# Altium schematic sheet [07] SYS_I2C_UART.SchDoc("[07] SYS_I2C_UART")
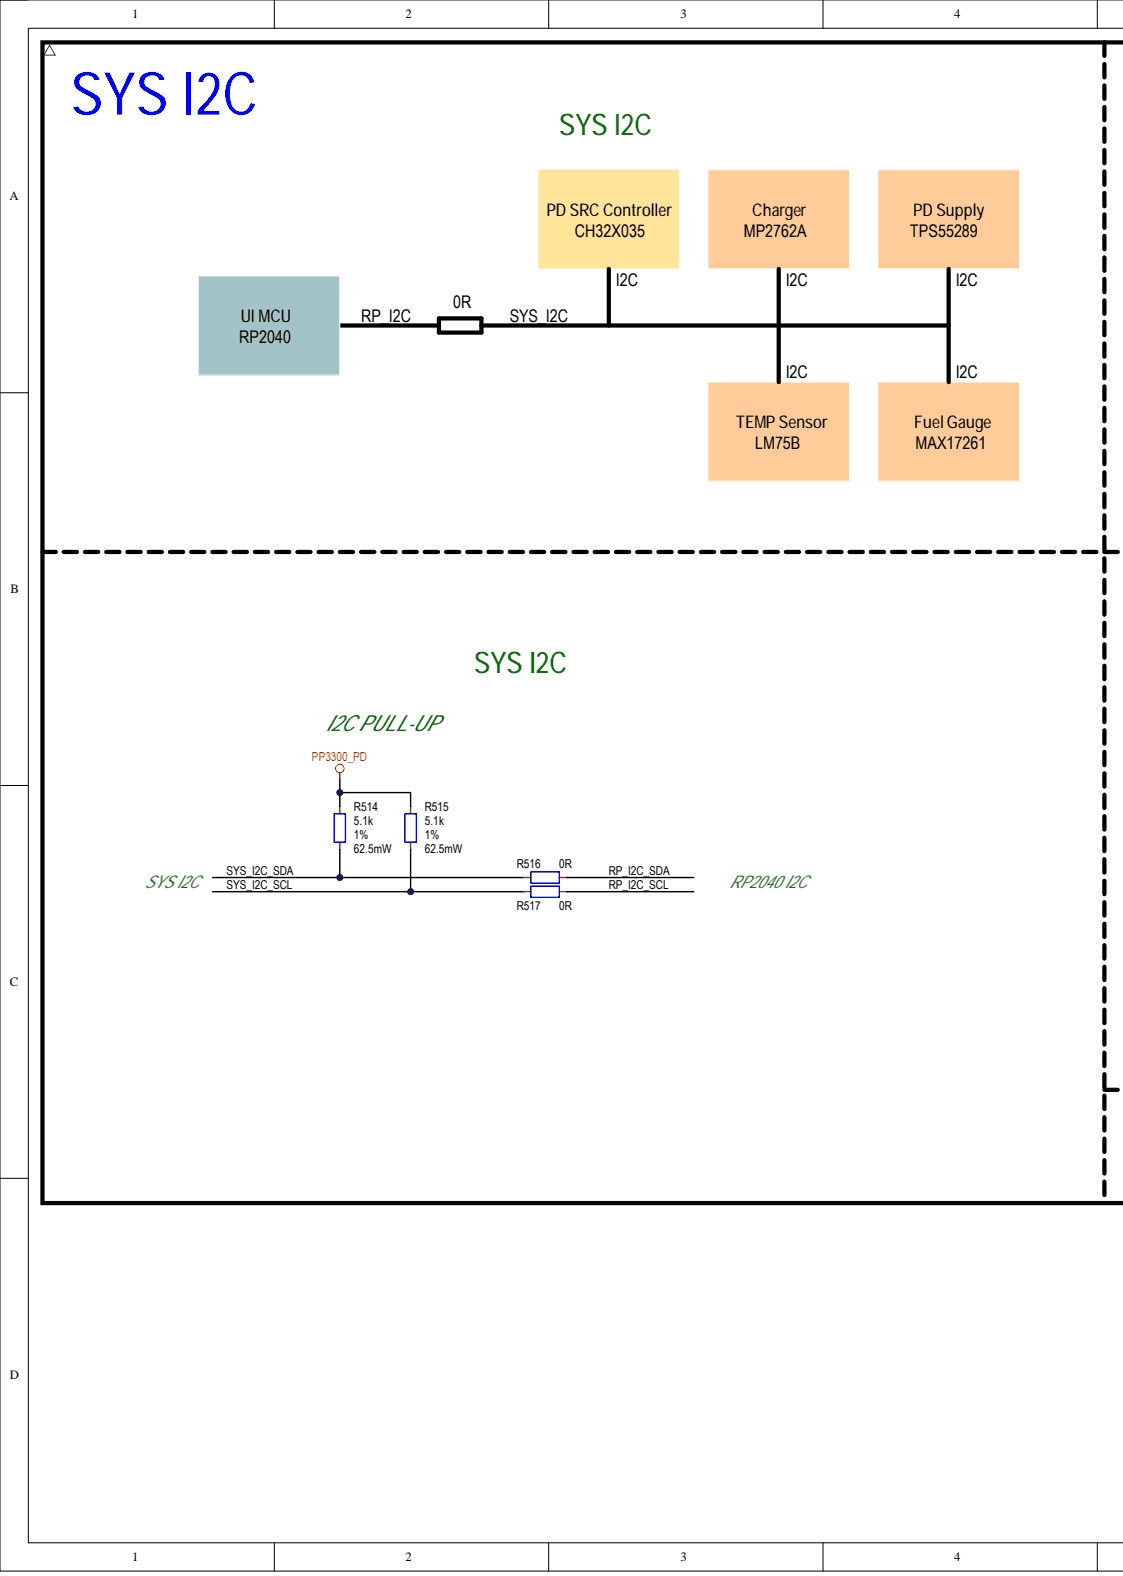
[diagram p0: [07] SYS_I2C_UART.SchDoc, left]
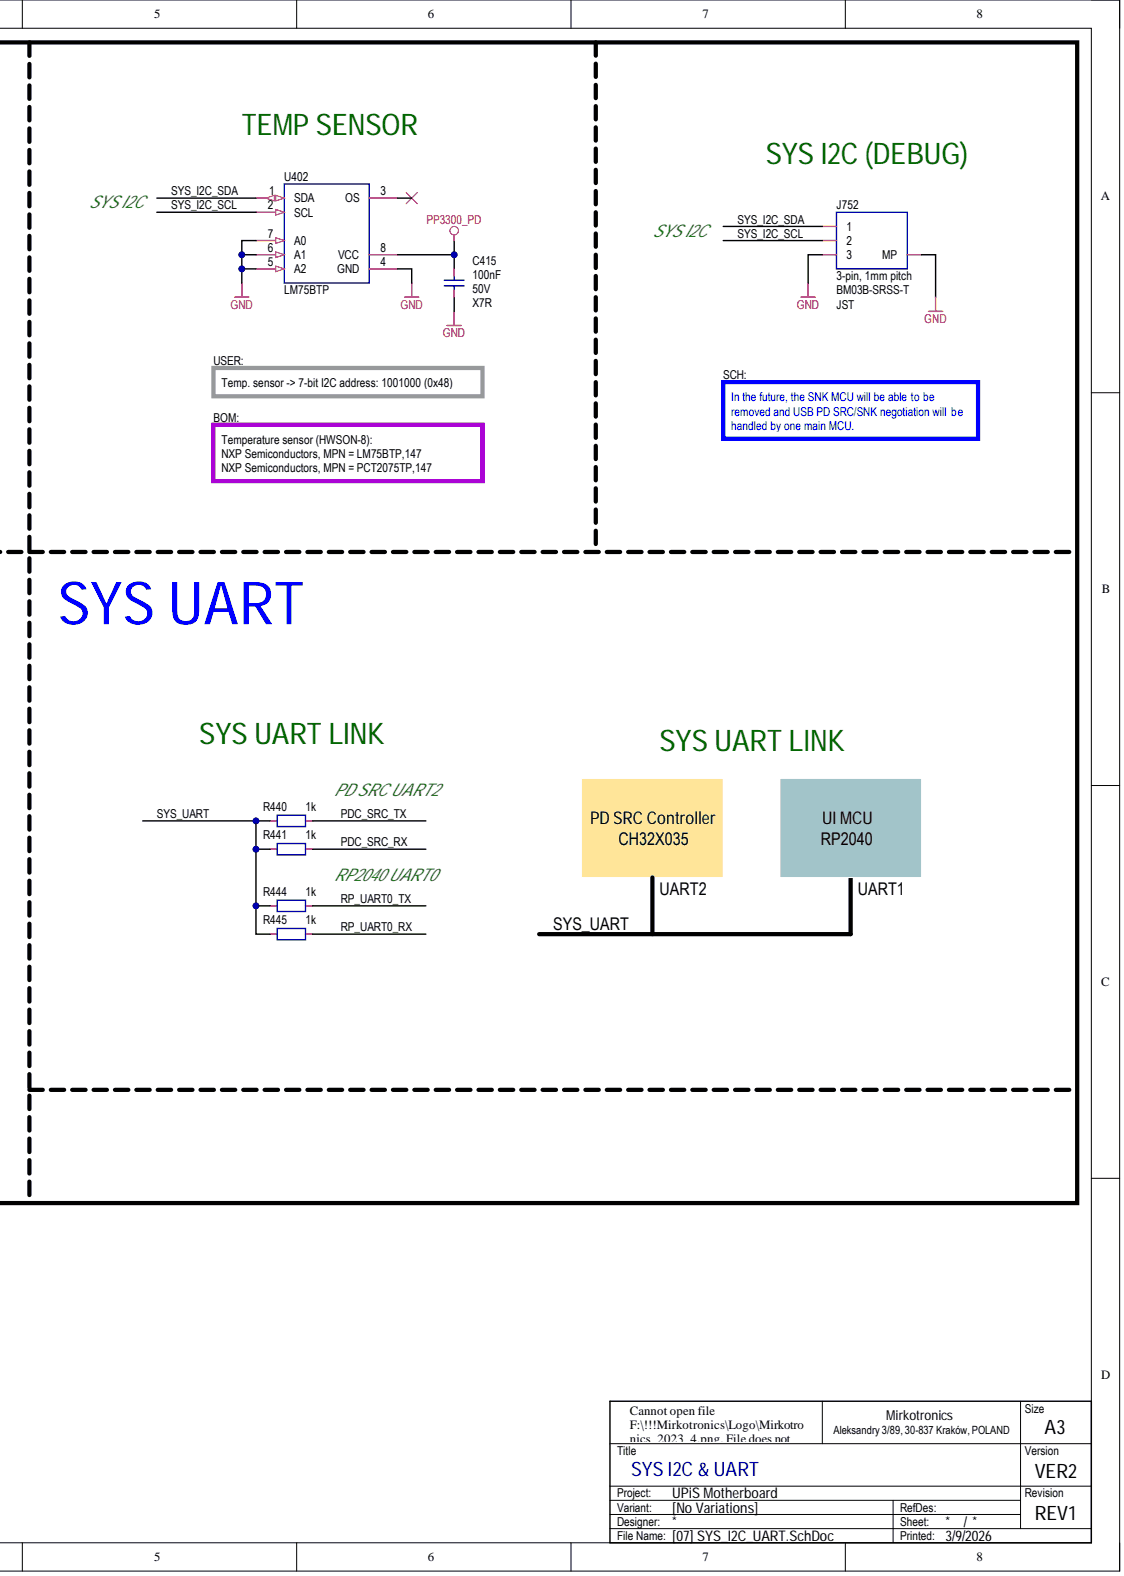
[diagram p1: [07] SYS_I2C_UART.SchDoc, right]

## Parts
C415  100nF  Dielectric: X7R  Voltage Rating: 50V
J752  3-pin, 1mm pitch  Manufacturer: JST  MPN: BM03B-SRSS-T
R440  1k
R441  1k
R444  1k
R445  1k
R514  5.1k  Power Rating: 62.5mW  Tolerance: 1%
R515  5.1k  Power Rating: 62.5mW  Tolerance: 1%
R516  0R
R517  0R
U402  LM75BTP

## Nets
GND: C415.1 J752.3 J752.MP U402.4(GND) U402.5(A2) U402.6(A1) U402.7(A0)
PP3300_PD: C415.2 R514.2 R515.2 U402.8(VCC)
PDC_SRC_RX: R441.1
PDC_SRC_TX: R440.1
RP_I2C_SCL: R517.2
RP_I2C_SDA: R516.2
RP_UART0_RX: R445.1
RP_UART0_TX: R444.1
SYS_I2C_SCL: J752.2 R515.1 R517.1 U402.2(SCL)
SYS_I2C_SDA: J752.1 R514.1 R516.1 U402.1(SDA)
SYS_UART: R440.2 R441.2 R444.2 R445.2

Not connected: U402 3(OS)

## Library data of the parts
C415 = CAP-0026: Capacitor; MLCC; GENERIC; TBD; TBD; TBD; TBD; SMD; 0402 (1005);; Manufacturer: Murata Electronics; MPN: GRM155R71H104KE14D; Component Body: 1.00 x 0.50mm; Database Table Name: CAPACITOR$; Packaging Type: Tape & Reel; Tolerance: 10%; Capacitance: 100nF; Component Height: 0.50mm; Component Kind: Standard; Component Subtype: MLCC; Component Type: Capacitor; Features: Standard; ID: CAP-0004; Mounting Type: SMD; Operating Temperature: -55°C to +125°C; Package (Case): 0402 (1005); ROHS Status: RoHS Compliant; Supplier 1: LCSC; Supplier 2: Mouser; Supplier 3: DigiKey; Supplier Part Number 1: C77020; Value: 100nF; Library Name: MirFol_db_local.DbLib
J752 = CON_1X03_COL_MP1: Connector; 1 Row;; Component Subtype: Crimp; Package (Case): SMD; Specification: Connector, crimp style, 4-pin, 1mm pitch, vertical, receptacle, SMD; Component Kind: Standard; Component Type: Connector; Mounting Type: SMD; Supplier Part Number 1: C160389; Value: 3-pin, 1mm pitch; Library Name: Pineberry_Lib.SchLib
R440 R441 R444 R445 = RES-0003: Resistor; Thick Film; 1k; 1%; 62.5mW; 100PPM; 50V; Standard; SMD; 0402 (1005);; Manufacturer: Yageo Corporation; MPN: RC0402FR-071KL; Component Body: 1.00 x 0.50mm; Database Table Name: RESISTOR$; Packaging Type: Tape & Reel; Component Height: 0.35mm; Component Kind: Standard; Component Subtype: Thick Film; Component Type: Resistor; Features: Standard; ID: RES-0003; Mounting Type: SMD; Operating Temperature: -55°C to +155°C; Package (Case): 0402 (1005); Power Rating: 62.5mW; Resistance: 1k; ROHS Status: RoHS Compliant; Supplier 1: LCSC; Supplier 2: Farnell; Supplier 3: Mouser; Supplier Part Number 1: C106235; Supplier Part Number 2: 9239235; Supplier Part Number 3: 603-RC0402FR-071KL; TCR: 100PPM; Tolerance: 1%; Value: 1k; Voltage Rating: 50V; Library Name: MirFol_db_local.DbLib
R514 R515 = RES-0058: Resistor; Thick Film; GENERIC; TBD; TBD; TBD; TBD; TBD; SMD; 0402 (1005);; Manufacturer: YAGEO; MPN: RC0402FR-075K1L; Component Body: 1.00 x 0.50mm; Database Table Name: RESISTOR$; Packaging Type: Tape & Reel; TCR: 100PPM; Voltage Rating: 50V; Component Height: 0.35mm; Component Kind: Standard; Component Subtype: Thick Film; Component Type: Resistor; Features: Standard; ID: RES-0058; Mounting Type: SMD; Operating Temperature: -55°C to +155°C; Package (Case): 0402 (1005); Resistance: 5.1k; ROHS Status: RoHS Compliant; Supplier 1: LCSC; Supplier 2: Mouser; Supplier 3: Digikey; Supplier Part Number 1: C105872; Supplier Part Number 2: 603-RC0402FR-075K1L; Supplier Part Number 3: 311-5.10KLRTR-ND; Value: 5.1k; Library Name: MirFol_db_local.DbLib
R516 R517 = RES-0057: Resistor; Thick Film; 0R; 1%; 62.5mW; 100PPM; 50V; Standard; SMD; 0402 (1005);; Manufacturer: YAGEO; MPN: RC0402FR-070RL; Component Body: 1.00 x 0.50mm; Database Table Name: RESISTOR$; Packaging Type: Tape & Reel; Component Height: TBD; Component Kind: Standard; Component Subtype: Thick Film; Component Type: Resistor; Features: Standard; ID: RES-0057; Mounting Type: SMD; Operating Temperature: -55°C to +155°C; Package (Case): 0402 (1005); Power Rating: 62.5mW; Resistance: 0R; ROHS Status: RoHS Compliant; Supplier 1: LCSC; Supplier 2: Mouser; Supplier 3: Digikey; Supplier Part Number 1: C106231; Supplier Part Number 2: 603-RC0402FR-070RL; Supplier Part Number 3: 311-0.0LRTR-ND; TCR: 100PPM; Tolerance: 1%; Value: 0R; Voltage Rating: 50V; Library Name: MirFol_db_local.DbLib
U402 = ICC_NXP_LM75B: ICC; Temperature Sensor;; Manufacturer: NXP Semiconductors; MPN: LM75BTP,147; Package (Case): HWSON-8; Component Kind: Standard; Component Subtype: Temperature Sensor; Component Type: IC; Mounting Type: SMD; Supplier 1: LCSC; Supplier 2: Mouser; Supplier 3: Digikey; Supplier Part Number 1: C5190812; Supplier Part Number 2: 771-LM75BTP147; Supplier Part Number 3: 568-9815-2-ND; Value: LM75BTP; Library Name: MirFol_lib.SchLib
